# Altium schematic sheet in_out.SchDoc(in_out)
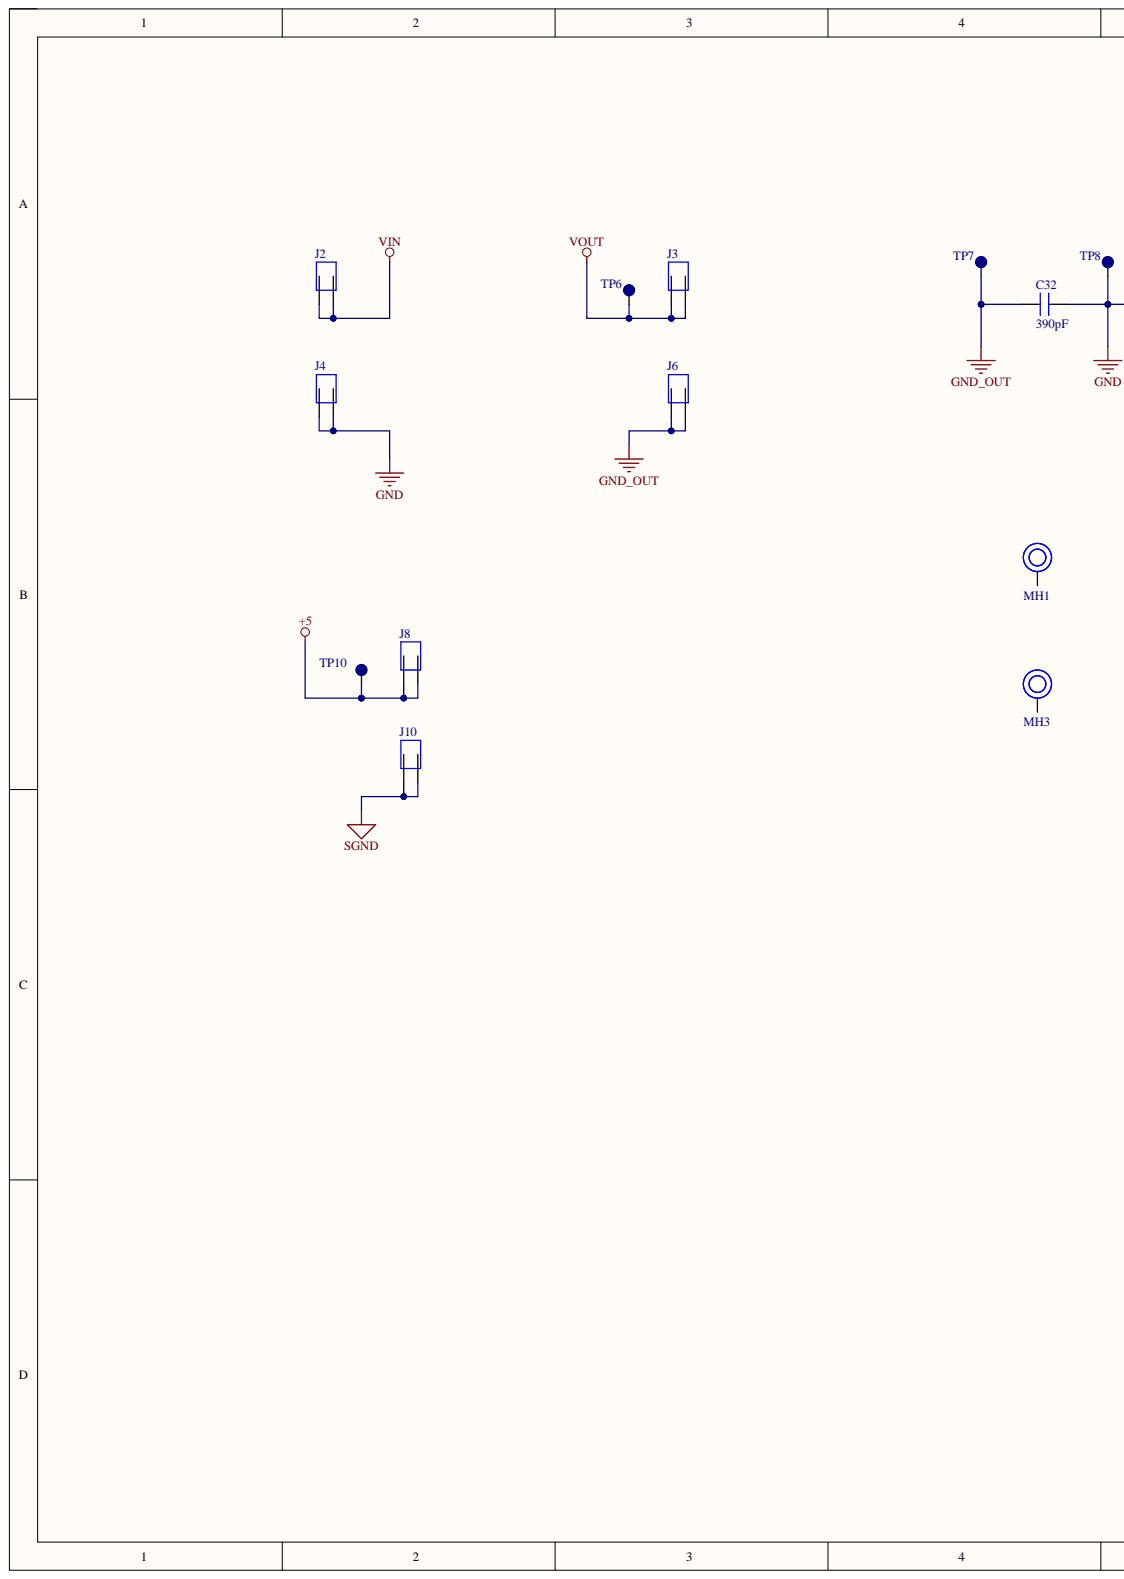
[diagram p0: in_out.SchDoc, left]
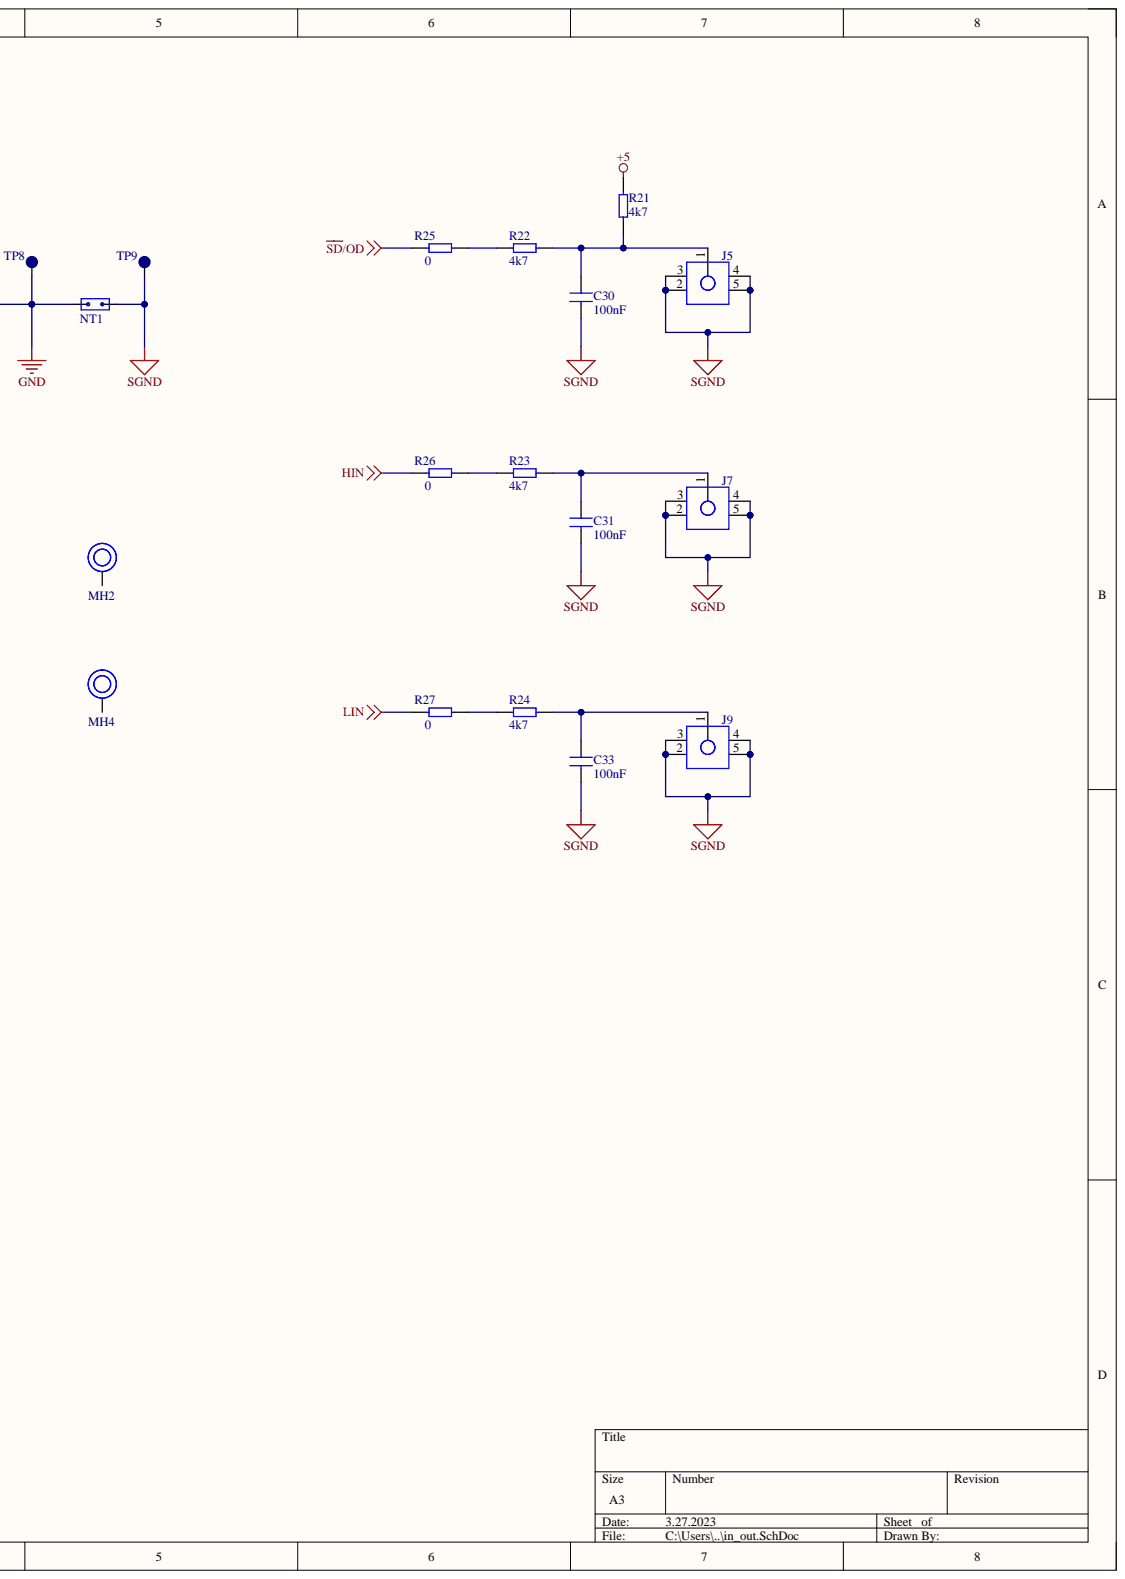
[diagram p1: in_out.SchDoc, right]

## Parts
C30  100nF  [Capacitor_0805]
C31  100nF  [Capacitor_0805]
C32  390pF  [Capacitor_1812]
C33  100nF  [Capacitor_0805]
J2  1289-STR  [1289STR]  (value not drawn)
J3  1289-STR  [1289STR]  (value not drawn)
J4  1289-STR  [1289STR]  (value not drawn)
J5  142-0701-301  [1420701301]  (value not drawn)
J6  1289-STR  [1289STR]  (value not drawn)
J7  142-0701-301  [1420701301]  (value not drawn)
J8  1289-STR  [1289STR]  (value not drawn)
J9  142-0701-301  [1420701301]  (value not drawn)
J10  1289-STR  [1289STR]  (value not drawn)
MH1  Mounting_Hole_M3  [Mounting_Hole_M3]  (value not drawn)  (no BOM)
MH2  Mounting_Hole_M3  [Mounting_Hole_M3]  (value not drawn)  (no BOM)
MH3  Mounting_Hole_M3  [Mounting_Hole_M3]  (value not drawn)  (no BOM)
MH4  Mounting_Hole_M3  [Mounting_Hole_M3]  (value not drawn)  (no BOM)
NT1  Net tie  [Net tie]  (value not drawn)
R21  4k7  [Resistor_1206]
R22  4k7  [Resistor_1206]
R23  4k7  [Resistor_1206]
R24  4k7  [Resistor_1206]
R25  0  [Resistor_0805]
R26  0  [Resistor_0805]
R27  0  [Resistor_0805]
TP6  Test point  [Test point]  (value not drawn)  (no BOM)
TP7  Test point  [Test point]  (value not drawn)  (no BOM)
TP8  Test point  [Test point]  (value not drawn)  (no BOM)
TP9  Test point  [Test point]  (value not drawn)  (no BOM)
TP10  Test point  [Test point]  (value not drawn)  (no BOM)

## Nets
+5: J8.1 J8.2 R21.2 TP10.1
~{SD}/OD: R25.1
GND: C32.2 J4.1 J4.2 NT1.1 TP8.1
GND_OUT: C32.1 J6.1 J6.2 TP7.1
HIN: R26.1
LIN: R27.1
SGND: C30.1 C31.1 C33.1 J5.2 J5.3 J5.4 J5.5 J7.2 J7.3 J7.4 J7.5 J9.2 J9.3 J9.4 J9.5 J10.1 J10.2 NT1.2 TP9.1
VIN: J2.1 J2.2
VOUT: J3.1 J3.2 TP6.1
NetC30_2: C30.2 J5.1 R21.1 R22.1
NetC31_2: C31.2 J7.1 R23.1
NetC33_2: C33.2 J9.1 R24.1
NetR22_2: R22.2 R25.2
NetR23_2: R23.2 R26.2
NetR24_2: R24.2 R27.2

Not connected: MH1 1; MH2 1; MH3 1; MH4 1

## Library data of the parts
C30 C31 C33 = Capacitor_0805: Capacitor - Standard SMD; Voltage: 12V; Capacitance: 100nF; Component Kind: Standard; Library Name: KN_ECART.SchLib
C32 = Capacitor_1812: Capacitor - Standard SMD; MPN: 1812B391K202CT; Voltage: 2kV; Capacitance: 390pF; Component Kind: Standard; Library Name: KN_ECART.SchLib
J2-J4 J6 J8 J10 = 1289-STR: Connector; Manufacturer_Name: Keystone Electronics; Component Kind: Standard; Datasheet Link: https://www.mouser.com/datasheet/2/215/Keystone_Electronics_12182018_1289-ST-1510776.pdf; Height: 14.37mm; Manufacturer_Part_Number: 1289-STR; Mouser Part Number: 534-1289-STR; Mouser Price/Stock: https://www.mouser.co.uk/ProductDetail/Keystone-Electronics/1289-STR?qs=0lSvoLzn4L%252BMUIbPVNHg8g%3D%3D; Library Name: KN_ECART.SchLib
J5 J7 J9 = 142-0701-301: Connector; Manufacturer_Name: Cinch Connectivity Solutions; Arrow Part Number: 142-0701-301; Arrow Price/Stock: https://www.arrow.com/en/products/142-0701-301/cinch-connectivity-solutions?region=nac; Component Kind: Standard; Datasheet Link: https://datasheet.datasheetarchive.com/originals/distributors/Datasheets_SAMA/2ec9b041f970d014d2778887508fd87c.pdf; Design Item ID: 142-0701-301; Height: 9.779mm; Manufacturer_Part_Number: 142-0701-301; Mouser Part Number: 530-142-0701-301; Mouser Price/Stock: https://www.mouser.co.uk/ProductDetail/Johnson-Cinch-Connectivity-Solutions/142-0701-301?qs=VqHsQWpF6un5h9kBF%252BTxDw%3D%3D; Library Name: KN_ECART.SchLib; Source: KN_ECART.SchLib
R21-R24 = Resistor_1206: Resistor - Standard SMD; Component Kind: Standard; Resistance: 4k7; Library Name: KN_ECART.SchLib
R25-R27 = Resistor_0805: Resistor - Standard SMD; Component Kind: Standard; Resistance: 0; Library Name: KN_ECART.SchLib
NT1 = Net tie: Component Kind: Net Tie; Library Name: KN_ECART.SchLib
MH1-MH4 = Mounting_Hole_M3: Library Name: KN_ECART.SchLib
TP6-TP10 = Test point: Library Name: KN_ECART.SchLib
